# Altium schematic sheet CPU.SchDoc(CPU)
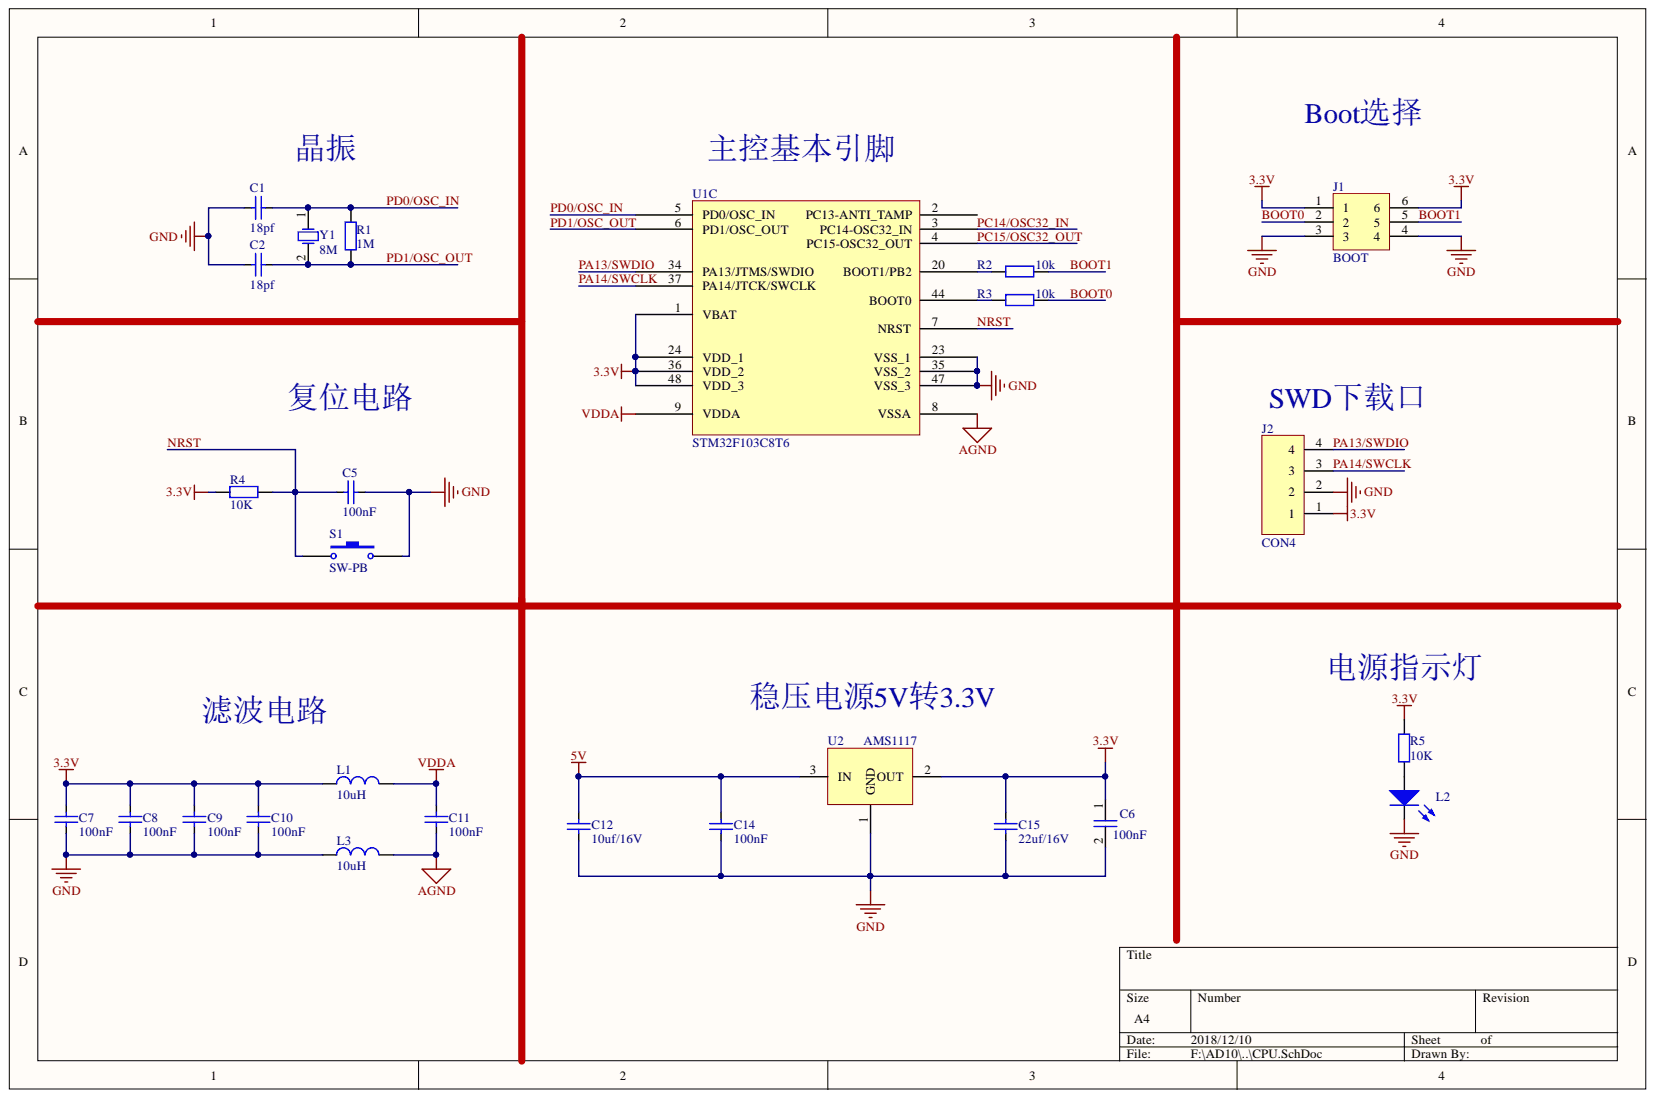
[diagram p0: CPU.SchDoc]

## Parts
C1  18pf  [LC-0603_C]
C2  18pf  [LC-0603_C]
C5  100nF  [LC-0603_C]
C6  100nF  [LC-0603_C]
C7  100nF  [LC-0603_C]
C8  100nF  [LC-0603_C]
C9  100nF  [LC-0603_C]
C10  100nF  [LC-0603_C]
C11  100nF  [LC-0603_C]
C12  10uf/16V  [LC-1210_C]
C14  100nF  [LC-0603_C]
C15  22uf/16V  [LC-1210_C]
J1  BOOT  [CON2*3]
J2  CON4  [4p白色  (2.54)_white]
L1  10uH  [LC-0603_L]
L2  LED_0603_RED  [LC-0603_LED_S1]  (value not drawn)
L3  10uH  [LC-0603_L]
R1  1M  [LC-0603_R]
R2  10k  [LC-0603_R]
R3  10k  [LC-0603_R]
R4  10K  [LC-0603_R]
R5  10K  [LC-0603_R]
S1  SW-PB  [KEY]
U1  STM32F103C8T6  [LC-LQFP-48_7x7x05P]  (part C)
U2  AMS1117  [LC-SOT-223]
Y1  8M  [晶振8MHZ]

## Nets
3.3V: C6.1 C7.2 C8.2 C9.2 C10.2 C15.2 J1.1 J1.6 J2.1 L1.1 R4.2 R5.1 U1.1(VBAT) U1.24(VDD_1) U1.36(VDD_2) U1.48(VDD_3) U2.2
5V: C12.2 C14.2 U2.3
AGND: C11.1 L3.2 U1.8(VSSA)
GND: C1.1 C2.1 C5.1 C6.2 C7.1 C8.1 C9.1 C10.1 C12.1 C14.1 C15.1 J1.3 J1.4 J2.2 L2.2 L3.1 S1.2 U1.23(VSS_1) U1.35(VSS_2) U1.47(VSS_3) U2.1
VDDA: C11.2 L1.2 U1.9(VDDA)
BOOT0: J1.2 R3.1
BOOT1: J1.5 R2.1
NRST: C5.2 R4.1 S1.1 U1.7(NRST)
PA13/SWDIO: J2.4 U1.34(PA13/JTMS/SWDIO)
PA14/SWCLK: J2.3 U1.37(PA14/JTCK/SWCLK)
PC14/OSC32_IN: U1.3(PC14-OSC32_IN)
PC15/OSC32_OUT: U1.4(PC15-OSC32_OUT)
PD0/OSC_IN: C1.2 R1.2 U1.5(PD0/OSC_IN) Y1.1
PD1/OSC_OUT: C2.2 R1.1 U1.6(PD1/OSC_OUT) Y1.2
NetL2_1: L2.1 R5.2
NetR2_2: R2.2 U1.20(BOOT1/PB2)
NetR3_2: R3.2 U1.44(BOOT0)

Not connected: U1 2(PC13-ANTI_TAMP)

## Library data of the parts
C1 C2 = Cap_0603: Capacitor; Value: Cap_0603; Component Kind: Standard; Library Name: 遗产.SchLib
C5 C7-C11 C14 = Cap_0603: Value: Cap_0603; Description: Cap_0603; Component Kind: Standard; Library Name: 工作原理库.SchLib
C6 = Cap: 无极性电容; Value: 0603; Published: 8-Jun-2000; PackageReference: RAD-0.3; LatestRevisionNote: Re-released for DXP Platform.; LatestRevisionDate: 17-Jul-2002; Component Kind: Standard; Publisher: Altium Limited; Library Name: RM_步兵主控板(梯形板)原理图库.SchLib
C12 C15 = Cap_1210: Description: Cap_1210; Component Kind: Standard; Library Name: 工作原理库.SchLib
J1 = CON3X2: Component Kind: Standard; Library Name: 遗产.SchLib
L1 L3 = Inductor: 电感0603; Value: 10uH; Component Kind: Standard; Library Name: RM_步兵主控板(梯形板)原理图库.SchLib
L2 = LED_0603_RED: LED; Component Kind: Standard; Library Name: 工作原理库.SchLib
R1-R3 = Res_0603: Resistor; Value: Res_0603; Component Kind: Standard; Library Name: 遗产.SchLib
R4 R5 = Res_0603: Value: Res_0603; Description: Res_0603; Component Kind: Standard; Library Name: 工作原理库.SchLib
S1 = SW-PB: 轻触开关; Component Kind: Standard; Library Name: 遗产.SchLib
U1 = STM32F103C8T6_3: Component Kind: Standard; Library Name: 遗产.SchLib
U2 = AMS1117_1: Component Kind: Standard; Library Name: RM_步兵主控板(梯形板)原理图库.SchLib
Y1 = 晶振: Crystal Oscillator; Simulation: XTAL; Published: 8-Jun-2000; PackageReference: R38; LatestRevisionNote: Re-released for DXP Platform.; LatestRevisionDate: 17-Jul-2002; Component Kind: Standard; Publisher: Altium Limited; Library Name: 遗产.SchLib
J2 = CON4: Component Kind: Standard; Library Name: 遗产.SchLib
